# Altium schematic sheet FMU_section.SchDoc
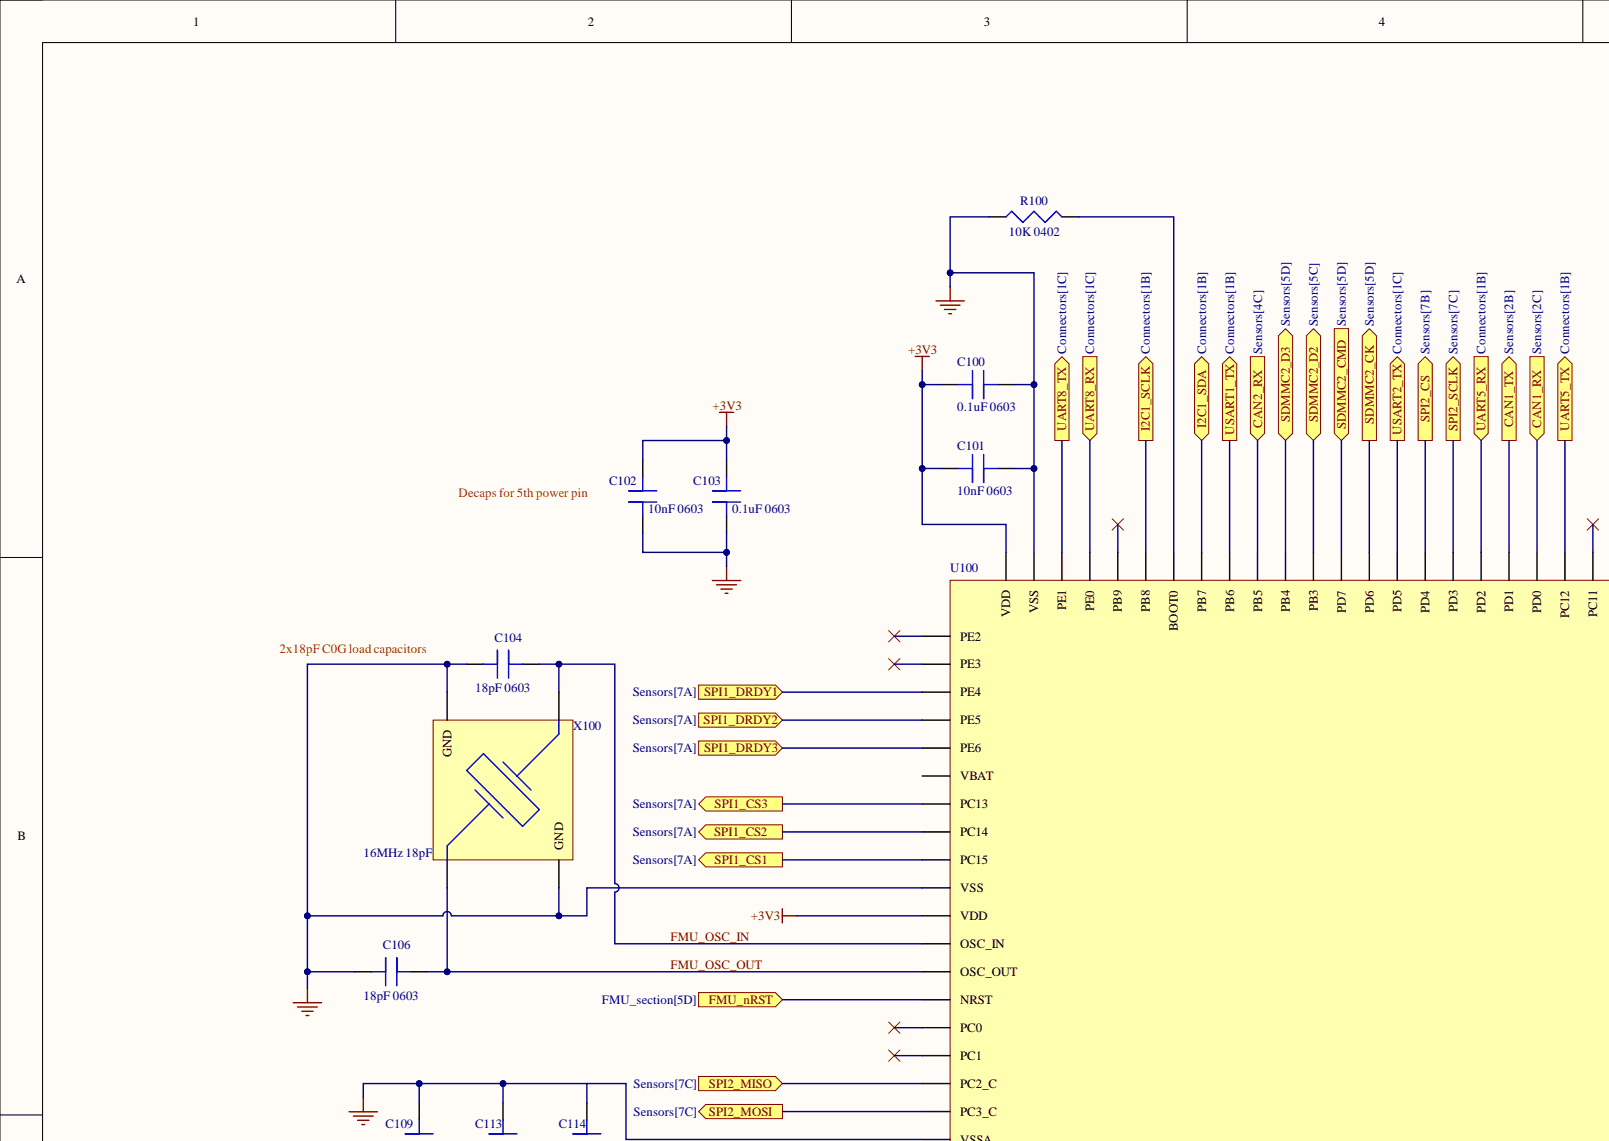
[diagram p0: FMU_section.SchDoc, top left]
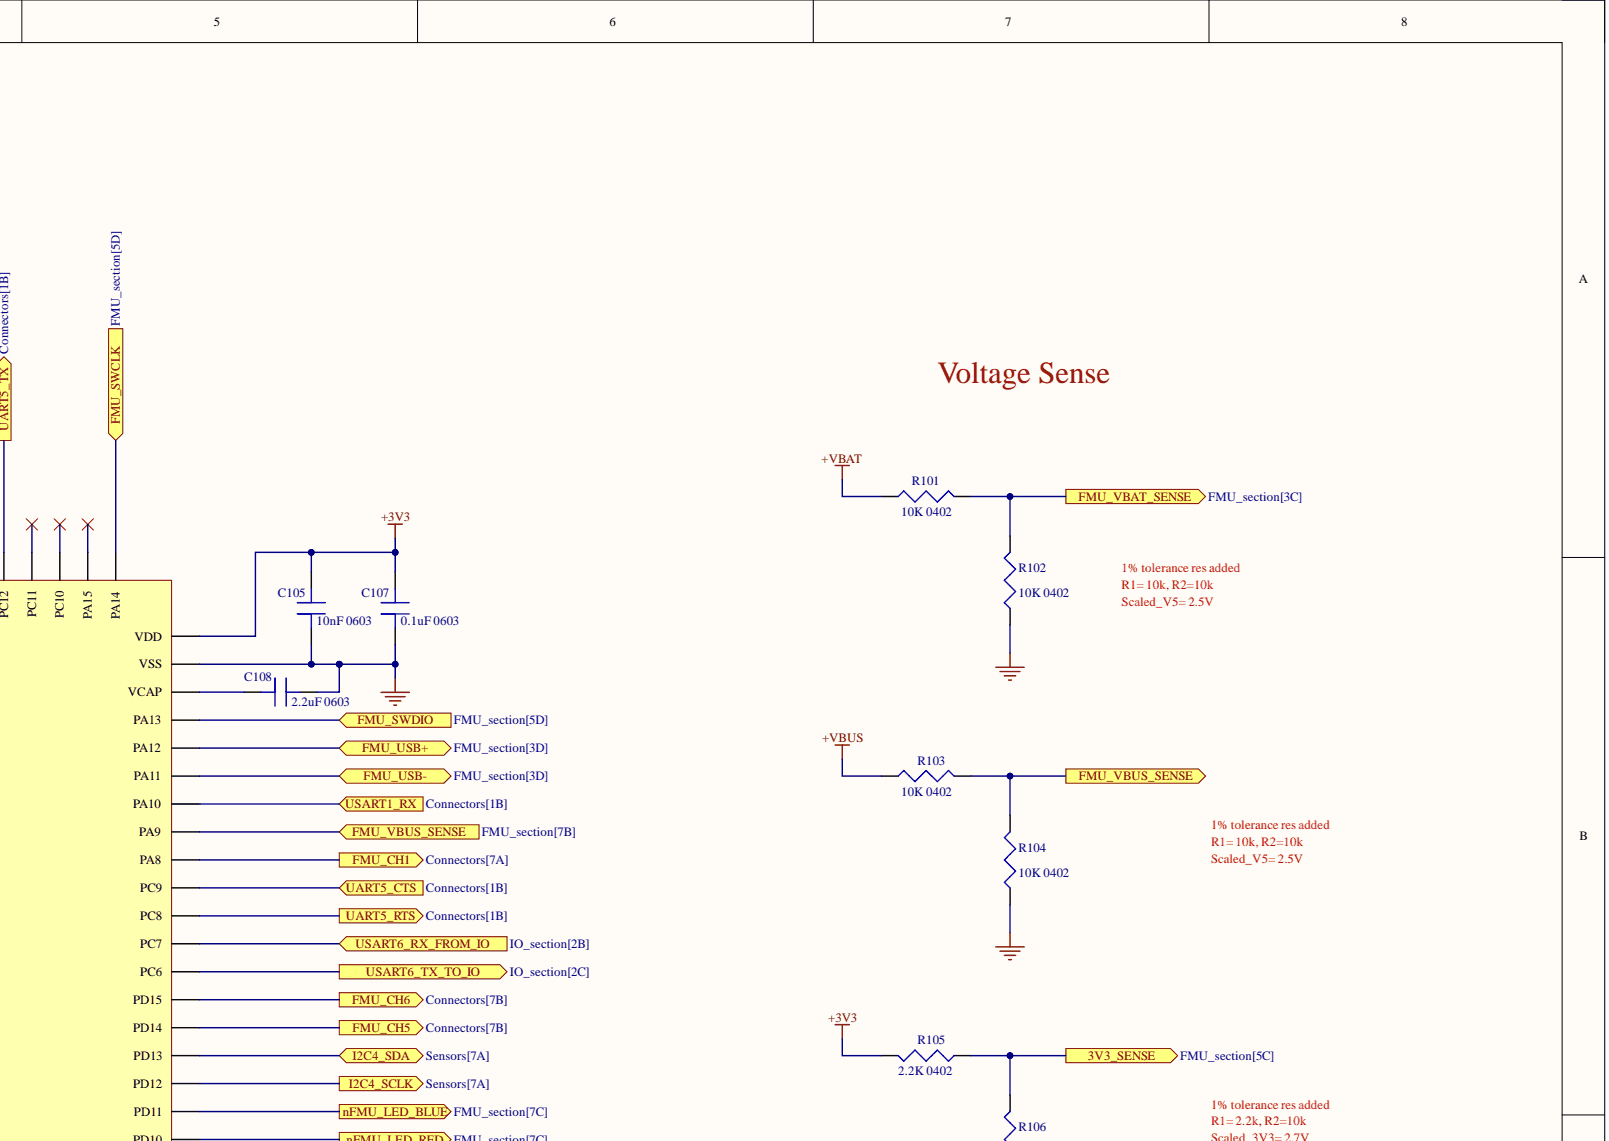
[diagram p1: FMU_section.SchDoc, top right]
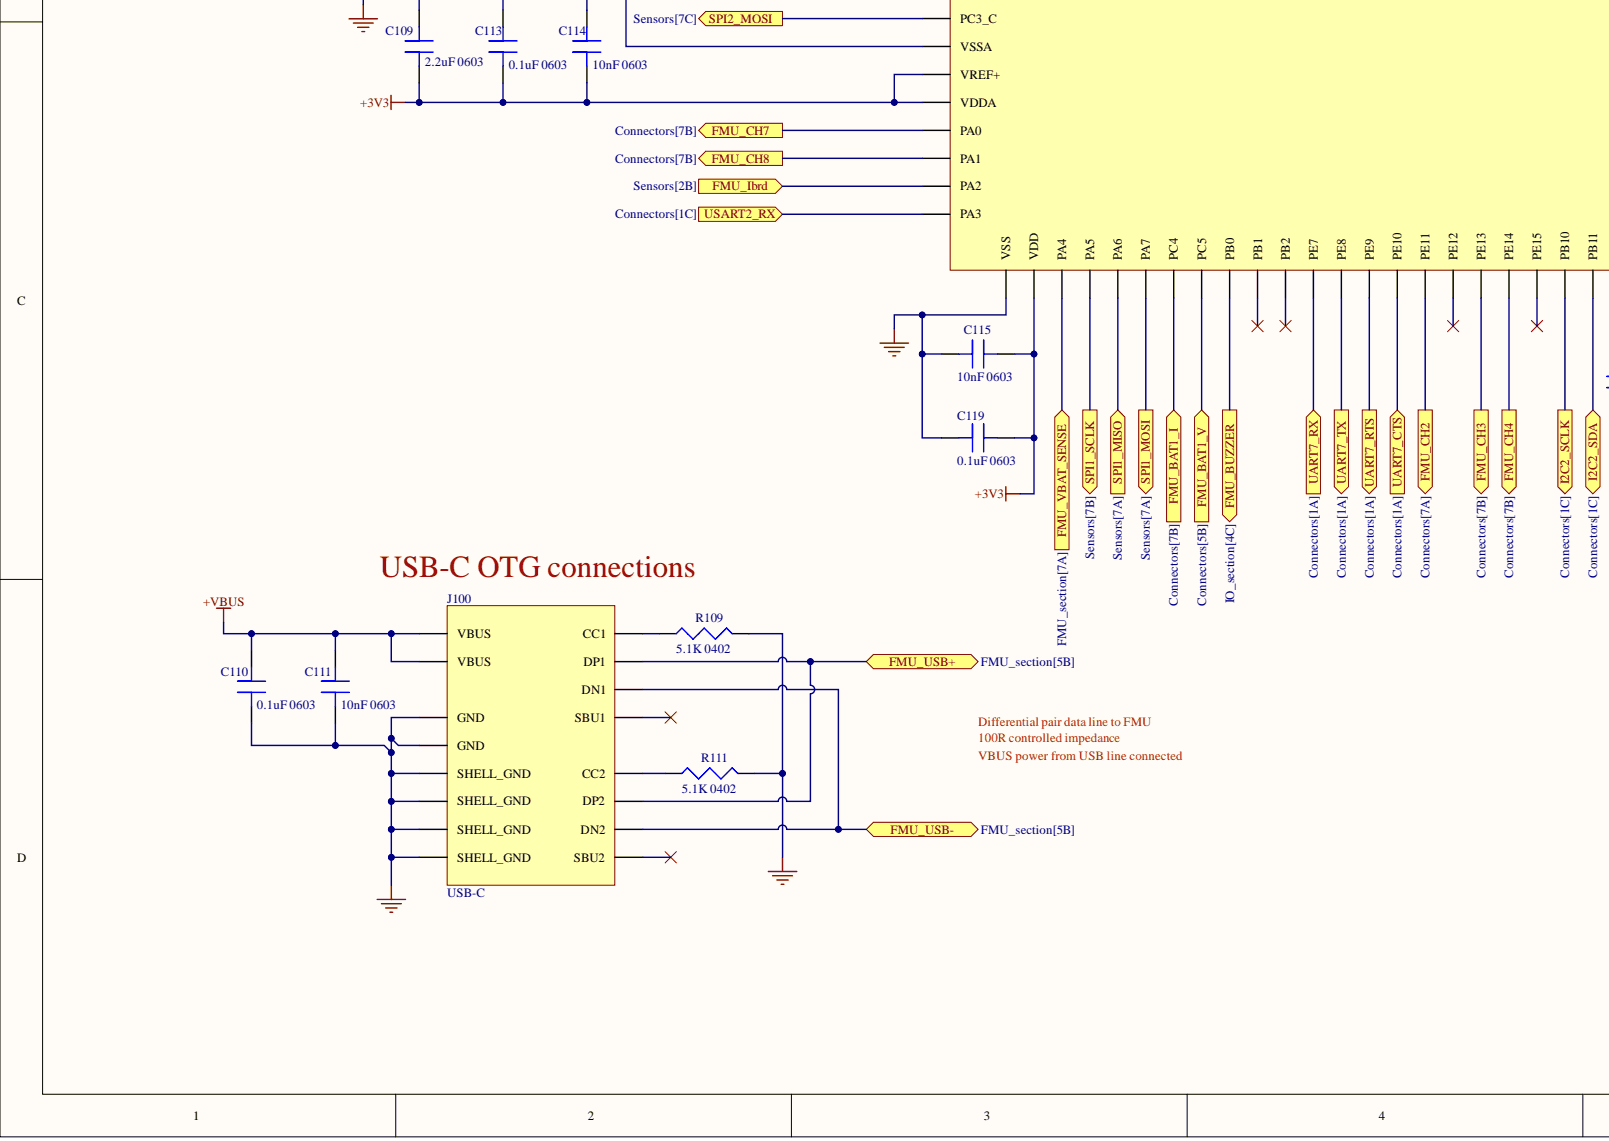
[diagram p2: FMU_section.SchDoc, bottom left]
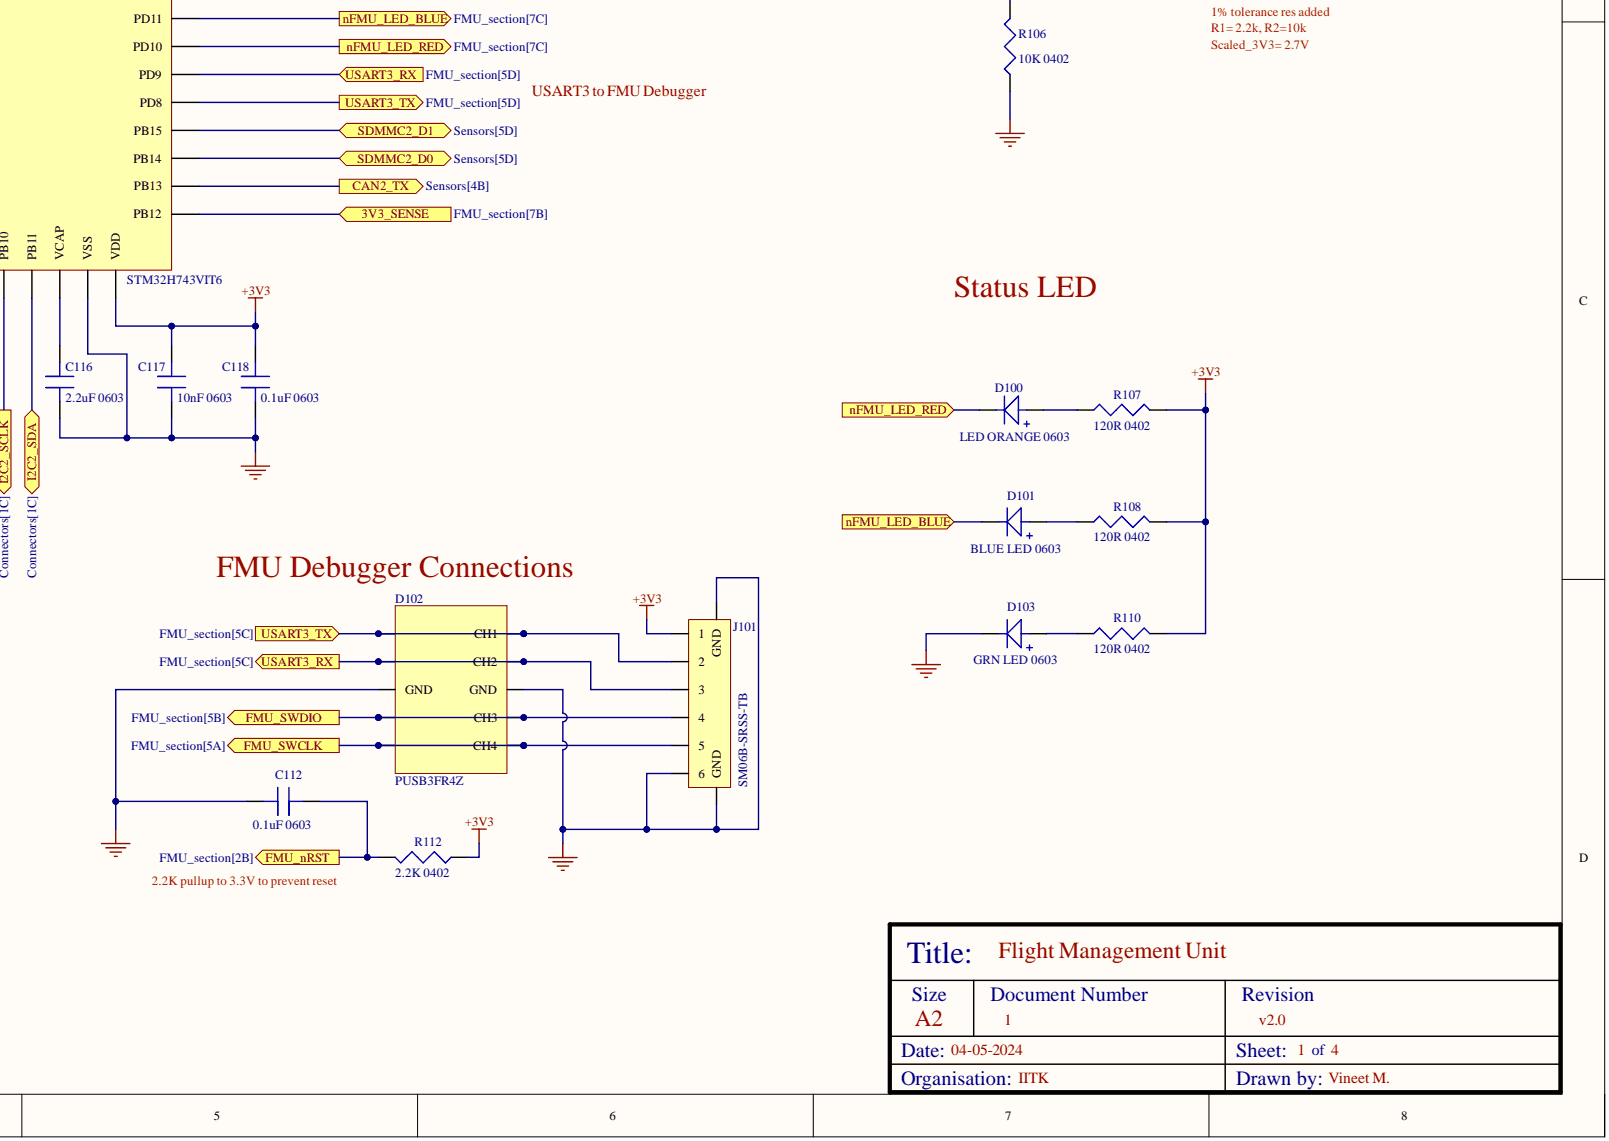
[diagram p3: FMU_section.SchDoc, bottom right]

## Parts
C100  0.1uF 0603  Case Code (Imperial): 0603  [C0603]
C101  10nF 0603  Capacitance: 10nF  Case Code (Imperial): 0603  [C0603]
C102  10nF 0603  Capacitance: 10nF  [C0603]
C103  0.1uF 0603  Case Code (Imperial): 0603  [C0603]
C104  18pF 0603  value 18pF  Case/Package: 0603  [C0603]
C105  10nF 0603  Capacitance: 10nF  [C0603]
C106  18pF 0603  value 18pF  Case/Package: 0603  [C0603]
C107  0.1uF 0603  Case Code (Imperial): 0603  [C0603]
C108  2.2uF 0603  value 2.2uF  Case/Package: 0603  [GRM18]
C109  2.2uF 0603  value 2.2uF  [GRM18]
C110  0.1uF 0603  [C0603]
C111  10nF 0603  Capacitance: 10nF  Case Code (Imperial): 0603  [C0603]
C112  0.1uF 0603  Case Code (Imperial): 0603  [C0603]
C113  0.1uF 0603  Case Code (Imperial): 0603  [C0603]
C114  10nF 0603  Capacitance: 10nF  Case Code (Imperial): 0603  [C0603]
C115  10nF 0603  Capacitance: 10nF  Case Code (Imperial): 0603  [C0603]
C116  2.2uF 0603  value 2.2uF  Case/Package: 0603  [GRM18]
C117  10nF 0603  Capacitance: 10nF  [C0603]
C118  0.1uF 0603  Case Code (Imperial): 0603  [C0603]
C119  0.1uF 0603  Case Code (Imperial): 0603  [C0603]
D100  LED ORANGE 0603  Case Code (Imperial): 0603  [LED_0603]
D101  BLUE LED 0603  [LED_0603]
D102  PUSB3FR4Z  [PUSB3FR4]
D103  GRN LED 0603  Case Code (Imperial): 0603  [LED_0603]
J100  USB-C  [GCT_USB4105-GF-A]
J101  SM06B-SRSS-TB  [SM06BSRSSTBLFSN]
R100  10K 0402  Case/Package: 0402  [R0402]
R101  10K 0402  Case/Package: 0402  [R0402]
R102  10K 0402  Case/Package: 0402  [R0402]
R103  10K 0402  Case/Package: 0402  [R0402]
R104  10K 0402  Case/Package: 0402  [R0402]
R105  2.2K 0402  Case Code (Imperial): 0402  [R0402]
R106  10K 0402  Case/Package: 0402  [R0402]
R107  120R 0402  [R0402]
R108  120R 0402  [R0402]
R109  5.1K 0402  Case/Package: 0402  [R0402]
R110  120R 0402  [R0402]
R111  5.1K 0402  Case/Package: 0402  [R0402]
R112  2.2K 0402  Case Code (Imperial): 0402  [R0402]
U100  STM32H743VIT6  [STM32H743VI]
X100  16MHz 18pF  Load Capacitance: 18pF  [ABM8]

## Ports
3V3_SENSE, CAN1_RX, CAN1_TX, CAN2_RX, CAN2_TX, FMU_BAT1_I, FMU_BAT1_V, FMU_BUZZER, FMU_CH1, FMU_CH2, FMU_CH3, FMU_CH4, FMU_CH5, FMU_CH6, FMU_CH7, FMU_CH8, FMU_Ibrd, FMU_nRST, FMU_SWCLK, FMU_SWDIO, FMU_USB+, FMU_USB-, FMU_VBAT_SENSE, FMU_VBUS_SENSE, I2C1_SCLK, I2C1_SDA, I2C2_SCLK, I2C2_SDA, I2C4_SCLK, I2C4_SDA, nFMU_LED_BLUE, nFMU_LED_RED, SDMMC2_CK, SDMMC2_CMD, SDMMC2_D0, SDMMC2_D1, SDMMC2_D2, SDMMC2_D3, SPI1_CS1, SPI1_CS2, SPI1_CS3, SPI1_DRDY1, SPI1_DRDY2, SPI1_DRDY3, SPI1_MISO, SPI1_MOSI, SPI1_SCLK, SPI2_CS, SPI2_MISO, SPI2_MOSI, SPI2_SCLK, UART5_CTS, UART5_RTS, UART5_RX, UART5_TX, UART7_CTS, UART7_RTS, UART7_RX, UART7_TX, UART8_RX, UART8_TX, USART1_RX, USART1_TX, USART2_RX, USART2_TX, USART3_RX, USART3_TX, USART6_RX_FROM_IO, USART6_TX_TO_IO

## Nets
+VBAT: R101.1
+VBUS: C110.2 C111.2 J100.A4_B9(VBUS) J100.B4_A9(VBUS) R103.1
GND: C100.2 C101.2 C102.1 C103.1 C104.1 C105.1 C106.1 C107.1 C108.2 C109.2 C110.1 C111.1 C112.2 C113.1 C114.1 C115.1 C116.1 C117.1 C118.1 C119.1 D102.3(GND) D102.8(GND) D103.2 J100.A1_B12(GND) J100.B1_A12(GND) J100.S1(SHELL_GND) J100.S2(SHELL_GND) J100.S3(SHELL_GND) J100.S4(SHELL_GND) J101.6 J101.7(GND) R100.1 R102.1 R104.1 R106.1 R109.2 R111.2 U100.10(VSS) U100.19(VSSA) U100.26(VSS) U100.49(VSS) U100.74(VSS) U100.99(VSS) X100.2(GND) X100.4(GND)
3V3_SENSE: C100.1 C101.1 C102.2 C103.2 C105.2 C107.2 C109.1 C113.2 C114.2 C115.2 C117.2 C118.2 C119.2 J101.1 R105.1 R105.2 R106.2 R107.2 R108.2 R110.2 R112.1 U100.11(VDD) U100.20(VREF+) U100.21(VDDA) U100.27(VDD) U100.50(VDD) U100.51(PB12) U100.75(VDD) U100.100(VDD) ; port 3V3_SENSE
CAN1_RX: U100.81(PD0) ; port CAN1_RX
CAN1_TX: U100.82(PD1) ; port CAN1_TX
CAN2_RX: U100.91(PB5) ; port CAN2_RX
CAN2_TX: U100.52(PB13) ; port CAN2_TX
FMU_BAT1_I: U100.32(PC4) ; port FMU_BAT1_I
FMU_BAT1_V: U100.33(PC5) ; port FMU_BAT1_V
FMU_BUZZER: U100.34(PB0) ; port FMU_BUZZER
FMU_CH1: U100.67(PA8) ; port FMU_CH1
FMU_CH2: U100.41(PE11) ; port FMU_CH2
FMU_CH3: U100.43(PE13) ; port FMU_CH3
FMU_CH4: U100.44(PE14) ; port FMU_CH4
FMU_CH5: U100.61(PD14) ; port FMU_CH5
FMU_CH6: U100.62(PD15) ; port FMU_CH6
FMU_CH7: U100.22(PA0) ; port FMU_CH7
FMU_CH8: U100.23(PA1) ; port FMU_CH8
FMU_Ibrd: U100.24(PA2) ; port FMU_Ibrd
FMU_nRST: C112.1 R112.2 U100.14(NRST) ; port FMU_nRST
FMU_OSC_IN: C104.2 U100.12(OSC_IN) X100.1
FMU_OSC_OUT: C106.2 U100.13(OSC_OUT) X100.3
FMU_SWCLK: D102.5(CH4) D102.6 J101.5 U100.76(PA14) ; port FMU_SWCLK
FMU_SWDIO: D102.4(CH3) D102.7 J101.4 U100.72(PA13) ; port FMU_SWDIO
FMU_USB+: J100.A6(DP1) J100.B6(DP2) U100.71(PA12) ; port FMU_USB+
FMU_USB-: J100.A7(DN1) J100.B7(DN2) U100.70(PA11) ; port FMU_USB-
FMU_VBAT_SENSE: R101.2 R102.2 U100.28(PA4) ; port FMU_VBAT_SENSE
FMU_VBUS_SENSE: R103.2 R104.2 U100.68(PA9) ; port FMU_VBUS_SENSE
I2C1_SCLK: U100.95(PB8) ; port I2C1_SCLK
I2C1_SDA: U100.93(PB7) ; port I2C1_SDA
I2C2_SCLK: U100.46(PB10) ; port I2C2_SCLK
I2C2_SDA: U100.47(PB11) ; port I2C2_SDA
I2C4_SCLK: U100.59(PD12) ; port I2C4_SCLK
I2C4_SDA: U100.60(PD13) ; port I2C4_SDA
nFMU_LED_BLUE: D101.2 U100.58(PD11) ; port nFMU_LED_BLUE
nFMU_LED_RED: D100.2 U100.57(PD10) ; port nFMU_LED_RED
SDMMC2_CK: U100.87(PD6) ; port SDMMC2_CK
SDMMC2_CMD: U100.88(PD7) ; port SDMMC2_CMD
SDMMC2_D0: U100.53(PB14) ; port SDMMC2_D0
SDMMC2_D1: U100.54(PB15) ; port SDMMC2_D1
SDMMC2_D2: U100.89(PB3) ; port SDMMC2_D2
SDMMC2_D3: U100.90(PB4) ; port SDMMC2_D3
SPI1_CS1: U100.9(PC15) ; port SPI1_CS1
SPI1_CS2: U100.8(PC14) ; port SPI1_CS2
SPI1_CS3: U100.7(PC13) ; port SPI1_CS3
SPI1_DRDY1: U100.3(PE4) ; port SPI1_DRDY1
SPI1_DRDY2: U100.4(PE5) ; port SPI1_DRDY2
SPI1_DRDY3: U100.5(PE6) ; port SPI1_DRDY3
SPI1_MISO: U100.30(PA6) ; port SPI1_MISO
SPI1_MOSI: U100.31(PA7) ; port SPI1_MOSI
SPI1_SCLK: U100.29(PA5) ; port SPI1_SCLK
SPI2_CS: U100.85(PD4) ; port SPI2_CS
SPI2_MISO: U100.17(PC2_C) ; port SPI2_MISO
SPI2_MOSI: U100.18(PC3_C) ; port SPI2_MOSI
SPI2_SCLK: U100.84(PD3) ; port SPI2_SCLK
UART5_CTS: U100.66(PC9) ; port UART5_CTS
UART5_RTS: U100.65(PC8) ; port UART5_RTS
UART5_RX: U100.83(PD2) ; port UART5_RX
UART5_TX: U100.80(PC12) ; port UART5_TX
UART7_CTS: U100.40(PE10) ; port UART7_CTS
UART7_RTS: U100.39(PE9) ; port UART7_RTS
UART7_RX: U100.37(PE7) ; port UART7_RX
UART7_TX: U100.38(PE8) ; port UART7_TX
UART8_RX: U100.97(PE0) ; port UART8_RX
UART8_TX: U100.98(PE1) ; port UART8_TX
USART1_RX: U100.69(PA10) ; port USART1_RX
USART1_TX: U100.92(PB6) ; port USART1_TX
USART2_RX: U100.25(PA3) ; port USART2_RX
USART2_TX: U100.86(PD5) ; port USART2_TX
USART3_RX: D102.2(CH2) D102.9 J101.3 U100.56(PD9) ; port USART3_RX
USART3_TX: D102.1(CH1) D102.10 J101.2 U100.55(PD8) ; port USART3_TX
USART6_RX_FROM_IO: U100.64(PC7) ; port USART6_RX_FROM_IO
USART6_TX_TO_IO: U100.63(PC6) ; port USART6_TX_TO_IO
NetC108_1: C108.1 U100.73(VCAP)
NetC116_2: C116.2 U100.48(VCAP)
NetD100_1: D100.1 R107.1
NetD101_1: D101.1 R108.1
NetD103_1: D103.1 R110.1
NetJ100_A5: J100.A5(CC1) R109.1
NetJ100_B5: J100.B5(CC2) R111.1
NetR100_2: R100.2 U100.94(BOOT0)

Not connected: J100 A8(SBU1) B8(SBU2); U100 1(PE2) 2(PE3) 6(VBAT) 15(PC0) 16(PC1) 35(PB1) 36(PB2) 42(PE12) 45(PE15) 77(PA15) 78(PC10) 79(PC11) 96(PB9)
